# Altium schematic sheet schema_slimme_meterV4.SchDoc(schema_slimme_meterV4)
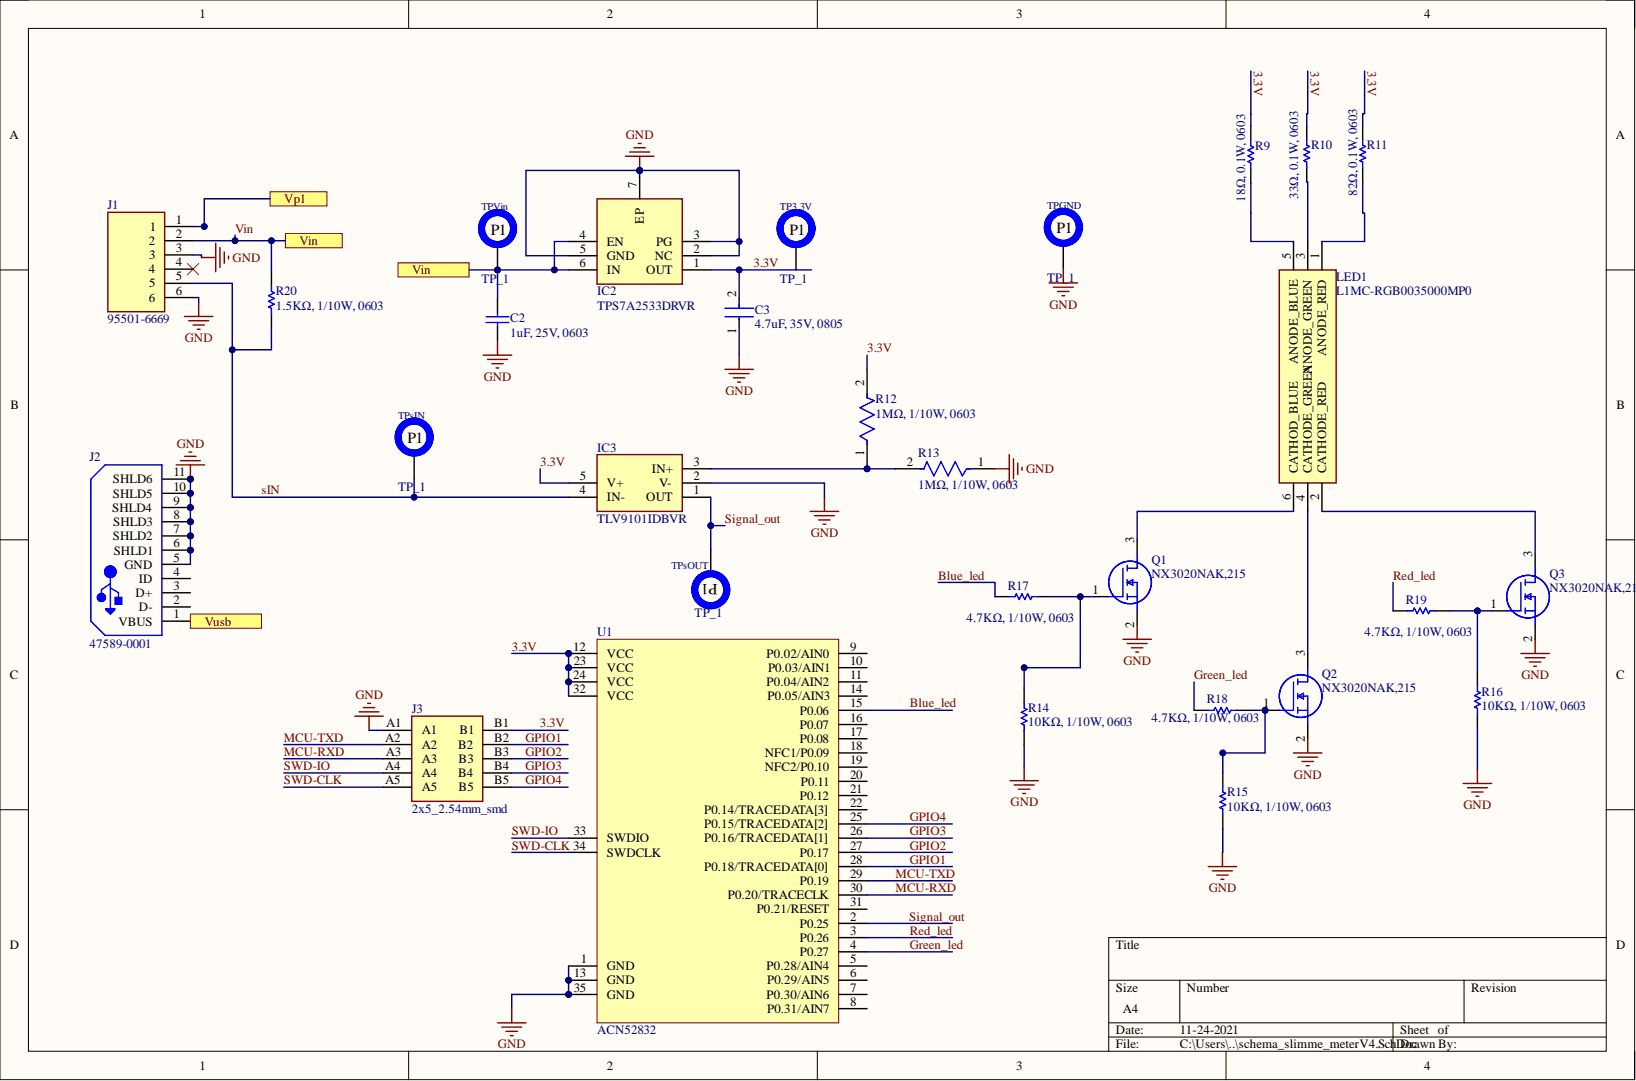
[diagram p0: schema_slimme_meterV4.SchDoc]

## Parts
C2  1uF, 25V, 0603  [CAPC1608X90X35LL10T15]
C3  4.7uF, 35V, 0805  [FP-GRM219-0_15-0_1-IPC_B]
IC2  TPS7A2533DRVR  [SON65P200X200X80-7N]
IC3  TLV9101IDBVR  [SOT95P280X145-5N]
J1  95501-6669  [95501-6669]
J2  47589-0001  [MOLX-47589-0001_V]
J3  2x5_2.54mm_smd  [10129383910001ALF]
LED1  L1MC-RGB0035000MP0  [L1MCRGB0035000MP0]
Q1  NX3020NAK,215  [SOT95P230X110-3N]
Q2  NX3020NAK,215  [SOT95P230X110-3N]
Q3  NX3020NAK,215  [SOT95P230X110-3N]
R9  18Ω, 0.1W, 0603  [RESC1608X55X30ML15T15]
R10  33Ω, 0.1W, 0603  [RESC1608X55X30ML15T15]
R11  82Ω, 0.1W, 0603  [RESC1608X55X30LL15T15]
R12  1MΩ, 1/10W, 0603  [FP-CRCW0603-e3-IPC_B]
R13  1MΩ, 1/10W, 0603  Case Code (Imperial): 0603  [FP-CRCW0603-e3-IPC_B]
R14  10KΩ, 1/10W, 0603  [RESC1609X50X30NL8T20]
R15  10KΩ, 1/10W, 0603  [RESC1609X50X30NL8T20]
R16  10KΩ, 1/10W, 0603  [RESC1609X50X30NL8T20]
R17  4.7KΩ, 1/10W, 0603  PackageReference: 0603  [RESC1608X55X30NL15T15]
R18  4.7KΩ, 1/10W, 0603  PackageReference: 0603  [RESC1608X55X30NL15T15]
R19  4.7KΩ, 1/10W, 0603  PackageReference: 0603  [RESC1608X55X30NL15T15]
R20  1.5KΩ, 1/10W, 0603  [RESC1608X55X30ML15T15]
TP3.3V  TP_1  [PCBComponent_1]  (no BOM)
TPGND  TP_1  [PCBComponent_1]  (no BOM)
TPsIN  TP_1  [PCBComponent_1]  (no BOM)
TPsOUT  TP_1  [PCBComponent_1]  (no BOM)
TPVin  TP_1  [PCBComponent_1]  (no BOM)
U1  ACN52832  [ACN52832]  (no BOM)

## Ports
Vin, Vp1, Vusb

## Nets
GND: C2.1 C3.1 IC2.2(NC) IC2.3(PG) IC2.5(GND) IC2.7(EP) IC3.2(V-) J1.3 J1.6 J2.5(GND) J2.6(SHLD1) J2.7(SHLD2) J2.8(SHLD3) J2.9(SHLD4) J2.10(SHLD5) J2.11(SHLD6) J3.A1 Q1.2 Q2.2 Q3.2 R13.1 R14.1 R15.1 R16.1 U1.1(GND) U1.13(GND) U1.35(GND)
3.3V: C3.2 IC2.1(OUT) IC3.5(V+) J3.B1 R9.2 R10.2 R11.2 R12.2 U1.12(VCC) U1.23(VCC) U1.24(VCC) U1.32(VCC)
Blue_led: R17.1 U1.15(P0.06)
GPIO1: J3.B2 U1.28(P0.18/TRACEDATA[0])
GPIO2: J3.B3 U1.27(P0.17)
GPIO3: J3.B4 U1.26(P0.16/TRACEDATA[1])
GPIO4: J3.B5 U1.25(P0.15/TRACEDATA[2])
Green_led: R18.1 U1.4(P0.27)
MCU-RXD: J3.A3 U1.30(P0.20/TRACECLK)
MCU-TXD: J3.A2 U1.29(P0.19)
Red_led: R19.1 U1.3(P0.26)
Signal_out: IC3.1(OUT) U1.2(P0.25)
sIN: IC3.4(IN-) J1.5 R20.1
SWD-CLK: J3.A5 U1.34(SWDCLK)
SWD-IO: J3.A4 U1.33(SWDIO)
Vin: C2.2 IC2.4(EN) IC2.6(IN) J1.2 R20.2 ; port Vin
Vp1: J1.1 ; port Vp1
Vusb: J2.1(VBUS) ; port Vusb
NetIC3_3: IC3.3(IN+) R12.1 R13.2
NetLED1_1: LED1.1(ANODE_RED) R11.1
NetLED1_2: LED1.2(CATHODE_RED) Q3.3
NetLED1_3: LED1.3(ANODE_GREEN) R10.1
NetLED1_4: LED1.4(CATHODE_GREEN) Q2.3
NetLED1_5: LED1.5(ANODE_BLUE) R9.1
NetLED1_6: LED1.6(CATHOD_BLUE) Q1.3
NetQ1_1: Q1.1 R14.2 R17.2
NetQ2_1: Q2.1 R15.2 R18.2
NetQ3_1: Q3.1 R16.2 R19.2

Not connected: J1 4; J2 2(D-) 3(D+) 4(ID); U1 5(P0.28/AIN4) 6(P0.29/AIN5) 7(P0.30/AIN6) 8(P0.31/AIN7) 9(P0.02/AIN0) 10(P0.03/AIN1) 11(P0.04/AIN2) 14(P0.05/AIN3) 16(P0.07) 17(P0.08) 18(NFC1/P0.09) 19(NFC2/P0.10) 20(P0.11) 21(P0.12) 22(P0.14/TRACEDATA[3]) 31(P0.21/RESET)

## Library data of the parts
C2 = CMP-2006-02459-1: Manufacturer: Murata; Part Number: GRM188R61E105KA12D; Component Kind: Standard; Library Name: Altium Content Vault
C3 = CMP-06035-049019-1: Chip Multilayer Ceramic Capacitors for General Purpose, 0805, 4.7uF, X5R, 15%, 10%, 35V; Capacitance: 4.7uF; Case Code (Imperial): 0805; Case Code (Metric): 2012; Case/Package: 0805; Component Kind: Standard; Depth: 1.25mm; Dielectric: X5R; Dielectric Material: Ceramic; Height: 0.85mm; Lead Free: Lead Free; Length: 2mm; Library Name: Altium Content Vault; Material: Ceramic; Max Operating Temperature: 85°C; Min Operating Temperature: -55°C; Mount: Surface Mount; Package Quantity: 1; Packaging: Tape and Reel; Termination: SMD/SMT; Thickness: 0.037inch; Tolerance: 10%; Voltage: 35V; Voltage Rating: 35V; Voltage Rating (DC): 35V; Weight: 0.000335oz; Width: 1.25mm
IC2 = TPS7A2533DRVR: Integrated Circuit; Manufacturer_Name: Texas Instruments; Component Kind: Standard; Datasheet Link: https://www.ti.com/lit/gpn/TPS7A25; Height: 0.8mm; Library Name: SamacSys.SchLib; Manufacturer_Part_Number: TPS7A2533DRVR
IC3 = TLV9101IDBVR: Integrated Circuit; Manufacturer_Name: Texas Instruments; Component Kind: Standard; Datasheet Link: http://www.ti.com/lit/ds/symlink/tlv9101.pdf; Height: 1.45mm; Library Name: SamacSys.SchLib; Manufacturer_Part_Number: TLV9101IDBVR
J1 = 95501-6669: Connector; Manufacturer_Name: Molex; Component Kind: Standard; Datasheet Link: https://componentsearchengine.com//95501-6669.pdf; Library Name: SamacSys.SchLib; Manufacturer_Part_Number: 95501-6669; Mouser2 Part Number: 538-95501-6669; Mouser2 Price/Stock: https://www.mouser.com/Search/Refine.aspx?Keyword=538-95501-6669
J2 = CMP-2000-05610-1: Right Angle Female Type AB Micro USB Connector, 30 V, 1.8 A, -30 to 85 degC, RoHS, Tape and Reel; Brand: Molex; Connector Type: MicroUSBTypeABreceptacle; Current Rating: 1.8A; Number of Contacts: 5Contact; Number of Ports: 1Port; Voltage Rating: 30VAC; Color: Black; Component Kind: Standard; Contact Material: CopperAlloy; Contact Plating: Gold; Datasheet URL: http://www.molex.com/webdocs/datasheets/pdf/en-us/0475890001_IO_CONNECTORS.pdf; Datasheet Version: 12/2016; Gender: Female; Library Name: Altium Content Vault; Manufacturer: Molex; Manufacturer URL: http://www.molex.com/; Mounting Angle: Right; Mounting Technology: SurfaceMount; Operating Temperature Range: -20Cto+85C; Package Description: Micro USB Connector, Pitch 0.65 mm, 5 Positions, Body 7.5 x 5.6 mm; Package Reference: 47589-0001; Package URL: http://www.molex.com/pdm_docs/sd/475890001_sd.pdf; Packaging: Reel; Product: MicroUSBTypeABConnectors; Product Category: USBConnectors; RoHS: True; Series: 47589; Standard: USB; Termination Style: SMD/SMT
J3 = 2x5_2.54mm_smd: Connector; Manufacturer_Name: Amphenol; Manufacturer_Part_Number: 10129383-910001ALF; Component Kind: Standard; Datasheet Link: https://cdn.amphenol-icc.com/media/wysiwyg/files/drawing/10129383.pdf; Height: 9.85mm; Library Name: SamacSys.SchLib
LED1 = L1MC-RGB0035000MP0: LED; Manufacturer_Name: Lumileds; Component Kind: Standard; Datasheet Link: https://www.lumileds.com/uploads/803/DS242-luxeon-multicolor-module-0-5w-datasheet-pdf; Height: 2.8mm; Manufacturer_Part_Number: L1MC-RGB0035000MP0
Q1-Q3 = NX3020NAK,215: MOSFET (N-Channel); Manufacturer_Name: Nexperia; Component Kind: Standard; Datasheet Link: https://assets.nexperia.com/documents/data-sheet/NX3020NAK.pdf; Height: 1.1mm; Manufacturer_Part_Number: NX3020NAK,215
R9 = CMP-2000-00306-1: Manufacturer: Panasonic; Part Number: ERJ3EKF18R0V; Component Kind: Standard; Library Name: Altium Content Vault
R10 = CMP-2000-00443-1: Manufacturer: Panasonic; Part Number: ERJ3EKF33R0V; Component Kind: Standard; Library Name: Altium Content Vault
R11 = CMP-2000-00662-1: Manufacturer: Panasonic; Part Number: ERJ3EKF82R0V; Component Kind: Standard; Library Name: Altium Content Vault
R12 R13 = CMP-2000-03016-2: RES Thick Film, 1MΩ, 5%, 0.1W, 200ppm/°C, 0603; Max Power Dissipation: 100mW; Resistance: 1MR; Voltage Rating: 75V; Case Code (Metric): 1608; Case/Package: 0603; Component Kind: Standard; Composition: Thick Film; Contact Plating: Tin; Depth: 0.85mm; Features: Automotive AEC-Q200; Height: 0.02inch; Length: 1.55mm; Library Name: Altium Content Vault; Max Operating Temperature: 155°C; Military Standard: Not; Min Operating Temperature: -55°C; Mount: Surface Mount; Number of Pins: 2; Number of Terminations: 2; Package Quantity: 1; Packaging: Tape and Reel; Power Rating: 100mW; Radiation Hardening: No; Temperature Coefficient: 200ppm/°C; Termination: SMD/SMT; Tolerance: 5%; Weight: 7.1E-05oz; Width: 0.85mm
  R12: Case Code (Imperial): 0603
R14-R16 = CMP-1666-00005-4: Chip Resistor, 10 KOhm, +/- 1%, 100 mW, -55 to 155 degC, 0603 (1608 Metric), RoHS, Tape and Reel; Manufacturer: Vishay Semiconductor; Voltage Rating: 75 V; Component Kind: Standard; ComponentLink1Description: Manufacturer URL; ComponentLink1URL: http://www.vishay.com/; ComponentLink2Description: Datasheet; ComponentLink2URL: https://datasheet.ciiva.com/11809/0900766b80d98afc-11809037.pdf; DatasheetVersion: 06/2012; Height: 0.45 mm; Length: 1.55 mm; Library Name: Altium Content Vault; Max Operating Temperature: 155 degC; Min Operating Temperature: -55 degC; Mounting Technology: Surface Mount; Package Quantity: 5000; PackageDescription: 2-Pin Surface Mount Device, Body 1.575 x 0.85 mm; PackageReference: 0603; Packaging: Tape and Reel; REACH SVHC: Unknown; RoHSCompliant: true
R17-R19 = CMP-1012-00586-3: Precision Thick Film Chip Resistor, 4.7 KOhm, +/- 1%, -55 to 155 degC, 0603 (1608 Metric), RoHS, Tape and Reel; Case\Package: 1205; Elements: 4; Power Rating: 250 mW; Resistance: 220 Ohm; Tolerance: 5%; Voltage Rating: 50 V; Case Code (Imperial): 1206; Case Code (Metric): 3216; Component Kind: Standard; ComponentLink1Description: Manufacturer URL; ComponentLink1URL: http://www.panasonic.com/; ComponentLink2Description: Datasheet; ComponentLink2URL: http://industrial.panasonic.com/cdbs/www-data/pdf/RDA0000/AOA0000C86.pdf; DatasheetVersion: Apr-15; Height: 0.024 inch; Length: 0.126 inch; Library Name: Altium Content Vault; Max Operating Temperature: 125 degC; Min Operating Temperature: -55 degC; Mount: Surface Mount; Package Quantity: 1; PackageDescription: 2-Pin Surface Mount Device, Body 1.6 x 0.85 mm; Packaging: Tape and Reel; Pins: 8; Radiation Hardening: No; REACH SVHC: No SVHC; RoHSCompliant: true; Type (Resistor): Thick Film; Width: 0.063 inch
R20 = CMP-1012-00528-2: Manufacturer: Panasonic; Part Number: ERJ-3EKF1501V; Component Kind: Standard; Library Name: Altium Content Vault
TP3.3V TPGND TPsIN TPsOUT TPVin = TestPoint: Library Name: TestpointLib.SchLib
U1 = ACN52832: Bluetooth-Module (802.15.1) Bluetooth Low Energy Smart Module; Supplier: Mouser; Supplier P/N: 364-ACN52832; Library Name: ACN52832_no_cutout.IntLib
